# Altium schematic sheet [11] Ethernet.SchDoc(BLK4)
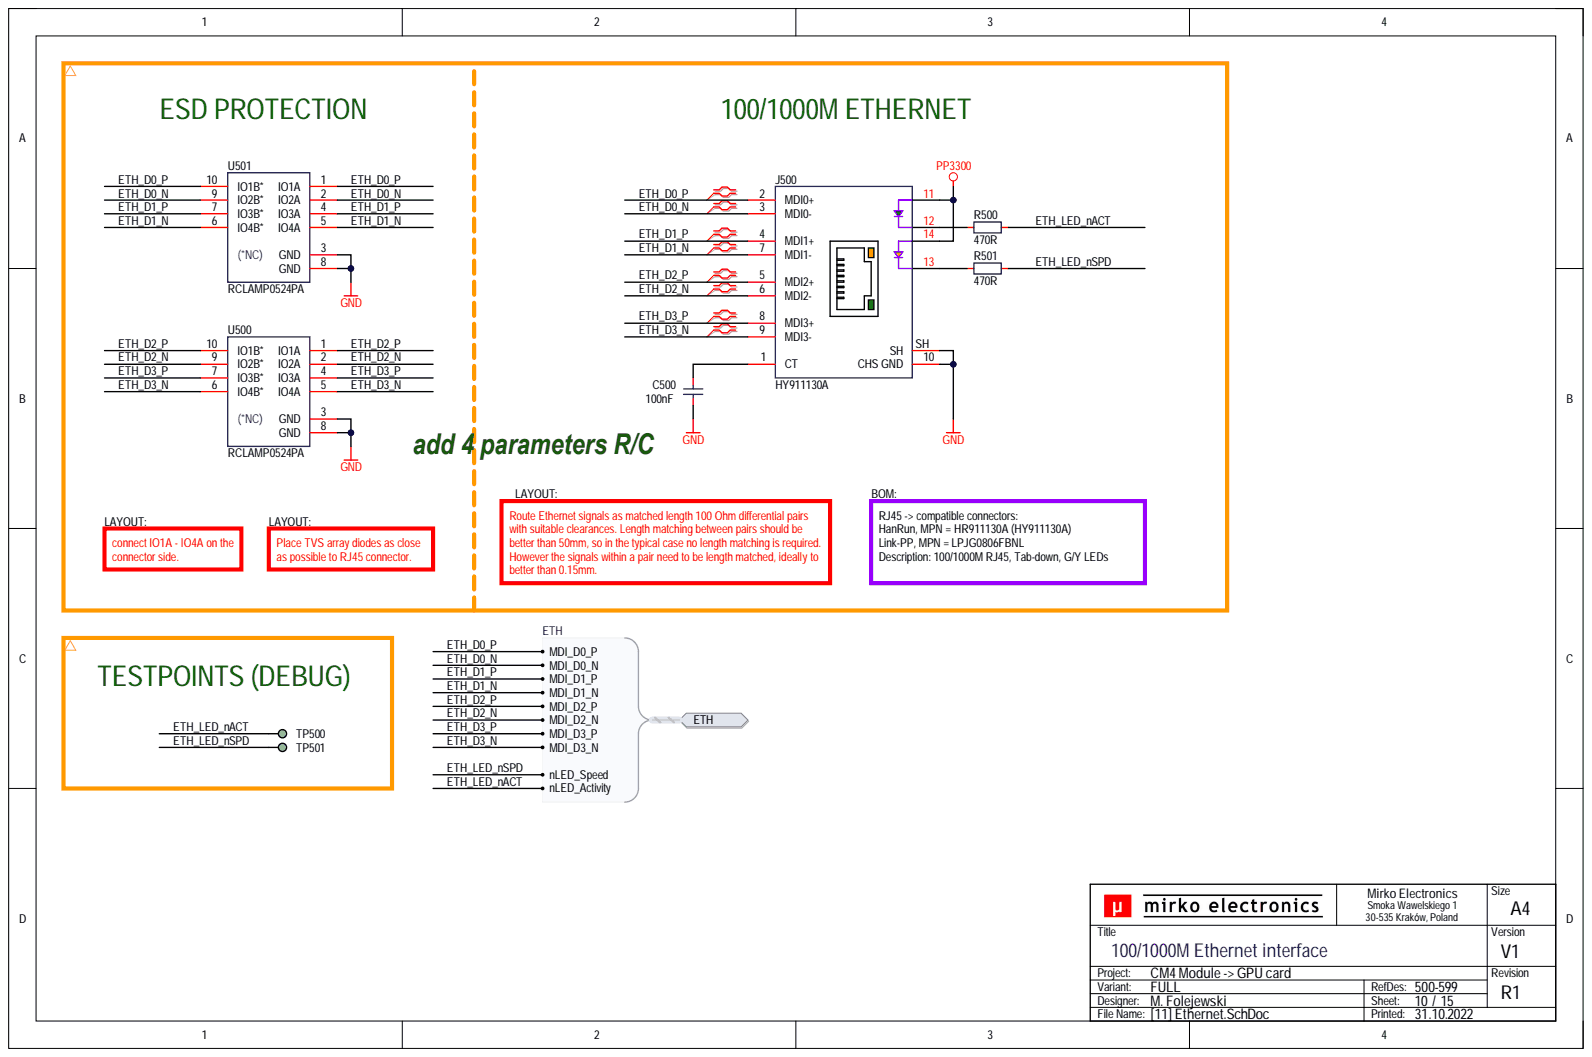
[diagram p0: [11] Ethernet.SchDoc]

## Parts
C500  100nF  [CAP_0603_R]
J500  HY911130A  [CON_WRT_7499110122]
R500  470R  [RES_0603_R]
R501  470R  [RES_0603_R]
TP500  DEBUG  [PCB_TP_DBG_50]  (value not drawn)  (no BOM)
TP501  DEBUG  [PCB_TP_DBG_50]  (value not drawn)  (no BOM)
U500  RCLAMP0524PA  [ICC_SLP2510P8_250X100X60_P50_R]
U501  RCLAMP0524PA  [ICC_SLP2510P8_250X100X60_P50_R]

## Ports
ETH, ETH.MDI_D0_N, ETH.MDI_D0_P, ETH.MDI_D1_N, ETH.MDI_D1_P, ETH.MDI_D2_N, ETH.MDI_D2_P, ETH.MDI_D3_N, ETH.MDI_D3_P, ETH.nLED_Activity, ETH.nLED_Speed

## Nets
GND: C500.1 J500.10(CHS_GND) J500.SH U500.3(GND) U500.8(GND) U501.3(GND) U501.8(GND)
PP3300: J500.11 J500.14
ETH_D0_N: J500.3(MDI0-) U501.2(IO2A) U501.9(IO2B*) ; port ETH
ETH_D0_P: J500.2(MDI0+) U501.1(IO1A) U501.10(IO1B*) ; port ETH
ETH_D1_N: J500.7(MDI1-) U501.5(IO4A) U501.6(IO4B*) ; port ETH
ETH_D1_P: J500.4(MDI1+) U501.4(IO3A) U501.7(IO3B*) ; port ETH
ETH_D2_N: J500.6(MDI2-) U500.2(IO2A) U500.9(IO2B*) ; port ETH
ETH_D2_P: J500.5(MDI2+) U500.1(IO1A) U500.10(IO1B*) ; port ETH
ETH_D3_N: J500.9(MDI3-) U500.5(IO4A) U500.6(IO4B*) ; port ETH
ETH_D3_P: J500.8(MDI3+) U500.4(IO3A) U500.7(IO3B*) ; port ETH
ETH_LED_nACT: R500.1 TP500.1 ; port ETH
ETH_LED_nSPD: R501.1 TP501.1 ; port ETH
NetC500_2: C500.2 J500.1(CT)
NetJ500_12: J500.12 R500.2
NetJ500_13: J500.13 R501.2

## Library data of the parts
C500 = CAP-0008: Capacitor; MLCC; 100nF; TBD; TBD; TBD; TBD; SMD; 0603 (1608);; Database Table Name: CAPACITOR$; Component Kind: Standard; Component Subtype: MLCC; Component Type: Capacitor; Manufacturer: TBD; Mounting Type: SMD; MPN: TBD; Package (Case): 0603 (1608); Supplier: ?; Value: 100nF; Library Name: MirFol_db_local.DbLib
J500 = CON_HAN_HY911130A: Connector; RJ45;; Manufacturer: HanRun; Component Kind: Standard; Component Subtype: RJ45; Component Type: Connector; Mounting Type: THT; MPN: HY911130A; Supplier: ?; Value: HY911130A; Library Name: MirFol_lib.SchLib
R500 R501 = RES-0042: Resistor; Thick Film; 470R; 1%; 100mW; 100PPM; 75V; Standard; SMD; 0603 (1608);; Manufacturer: Yageo Corporation; MPN: TBD; Database Table Name: RESISTOR$; Component Kind: Standard; Component Subtype: Thick Film; Component Type: Resistor; Mounting Type: SMD; Package (Case): 0603 (1608); Supplier: ?; Value: 470R; Library Name: MirFol_db_local.DbLib
TP500 TP501 = PCB_TP_DBG: PCB Marking; Test Point (Debug);; Component Subtype: -; Component Type: Testpoint; Mounting Type: -; Supplier: ?; Value: DEBUG; Library Name: MirFol_lib.SchLib
U500 U501 = ICC-0005: IC; TVS Arrays; ESD Protection; 4-Channel; 17kV Air; 12kV Contact; 0.3pF Capitance; TBD; SMD; TBD;; Manufacturer: Semtech Corporation; MPN: RCLAMP0524PATCT; Database Table Name: IC (MISC)$; Component Kind: Standard; Component Subtype: TVS Arrays; Component Type: IC; Mounting Type: SMD; Package (Case): TBD; Supplier: ?; Value: RCLAMP0524PA; Library Name: MirFol_db_local.DbLib
